# Altium schematic sheet Sheet3.SchDoc
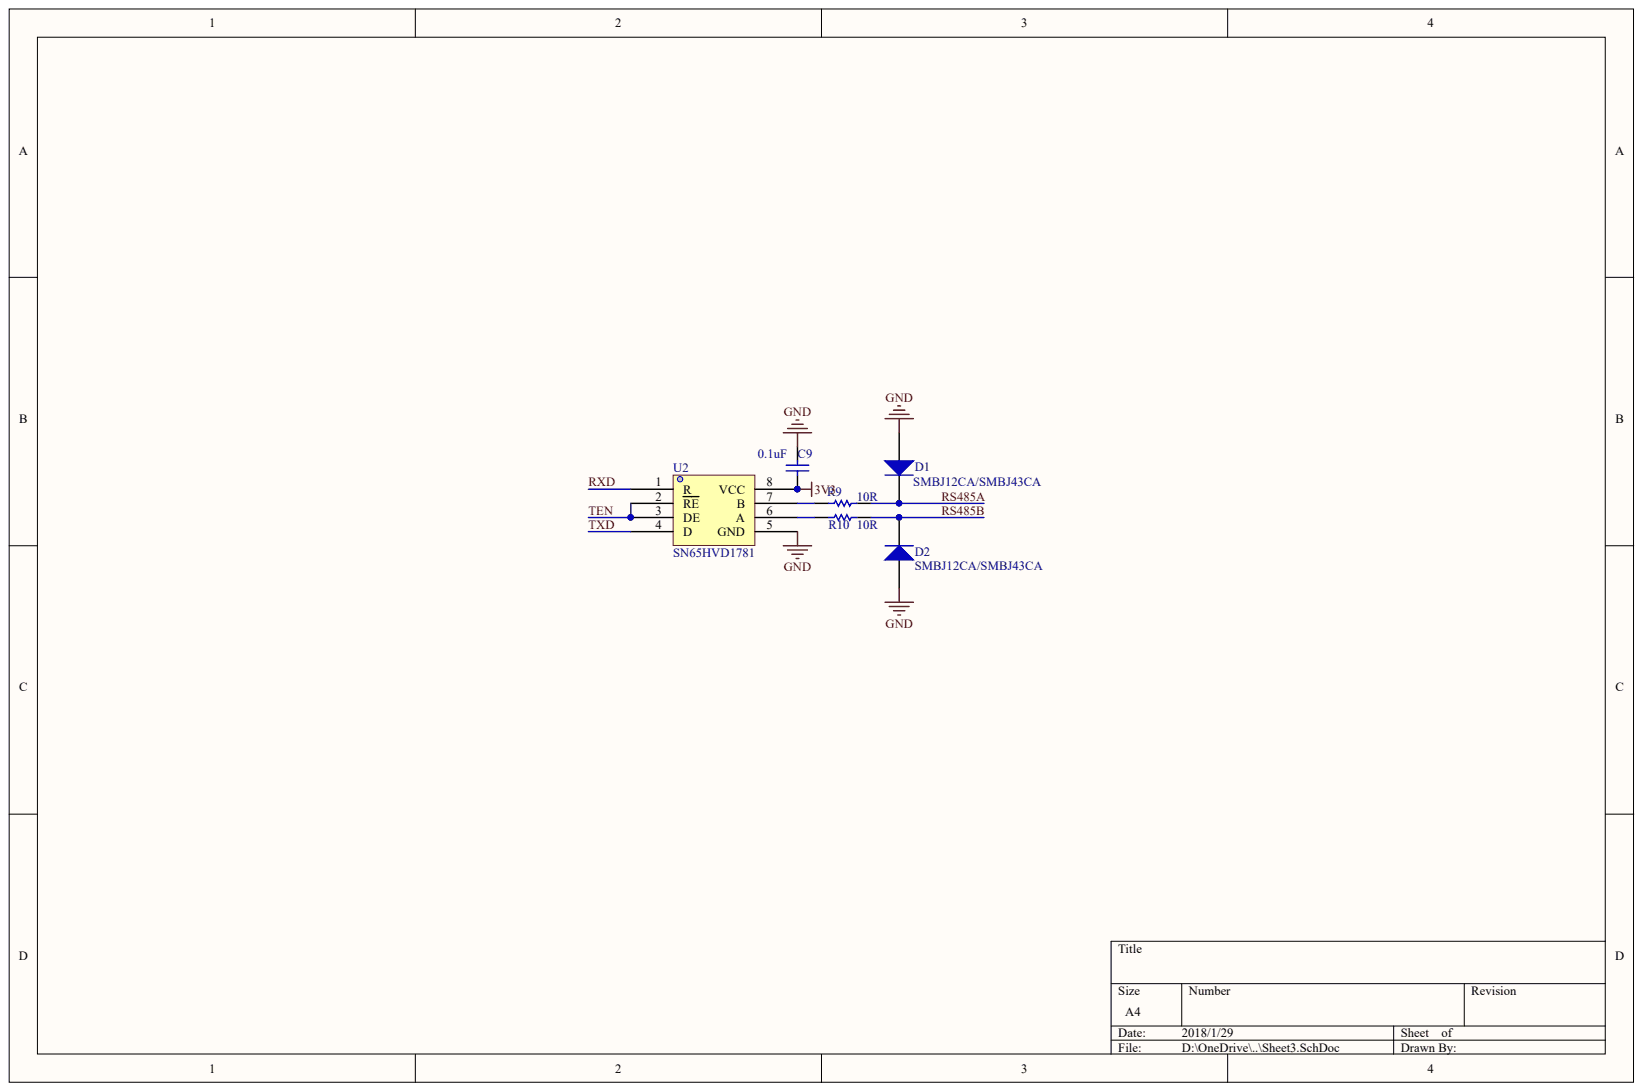
[diagram p0: Sheet3.SchDoc]

## Parts
C9  value 0.1uF  (hidden comment: Cap)
D1  SMBJ12CA/SMBJ43CA
D2  SMBJ12CA/SMBJ43CA
R9  value 10R  (hidden comment: Res1)
R10  value 10R  (hidden comment: Res1)
U2  SN65HVD1781

## Nets
3V3: C9.1 U2.8(VCC)
GND: C9.2 D1.1 D2.1 U2.5(GND)
RS485A: D1.2 R9.2
RS485B: D2.2 R10.2
RXD: U2.1(R)
TEN: U2.2(RE) U2.3(DE)
TXD: U2.4(D)
NetR9_1: R9.1 U2.7(B)
NetR10_1: R10.1 U2.6(A)

## Library data of the parts
C9 D1 D2 R9 R10 U2 = (no symbol name)
  C9: Published: 8-Jun-2000; LatestRevisionDate: 17-Jul-2002; LatestRevisionNote: Re-released for DXP Platform.; PackageReference: RAD-0.3
  D1 D2: Published: 8-Jun-2000; LatestRevisionDate: 17-Jul-2002; LatestRevisionNote: Re-released for DXP Platform.; DatasheetVersion: 1998; PackageVersion: Aug-1999; PackageReference: DO-201AD; ComponentLink1Description: Manufacturer Link; ComponentLink1URL: http://www.fairchildsemi.com/; ComponentLink2Description: Datasheet; ComponentLink2URL: http://www.fairchildsemi.com/ds/1N%2F1N5400.pdf
  R9 R10: Published: 8-Jun-2000; LatestRevisionDate: 17-Jul-2002; LatestRevisionNote: Re-released for DXP Platform.; PackageReference: AXIAL-0.3
  C9 D1 D2 R9 R10: Publisher: Altium Limited
